# Altium schematic sheet Sheet5.SchDoc
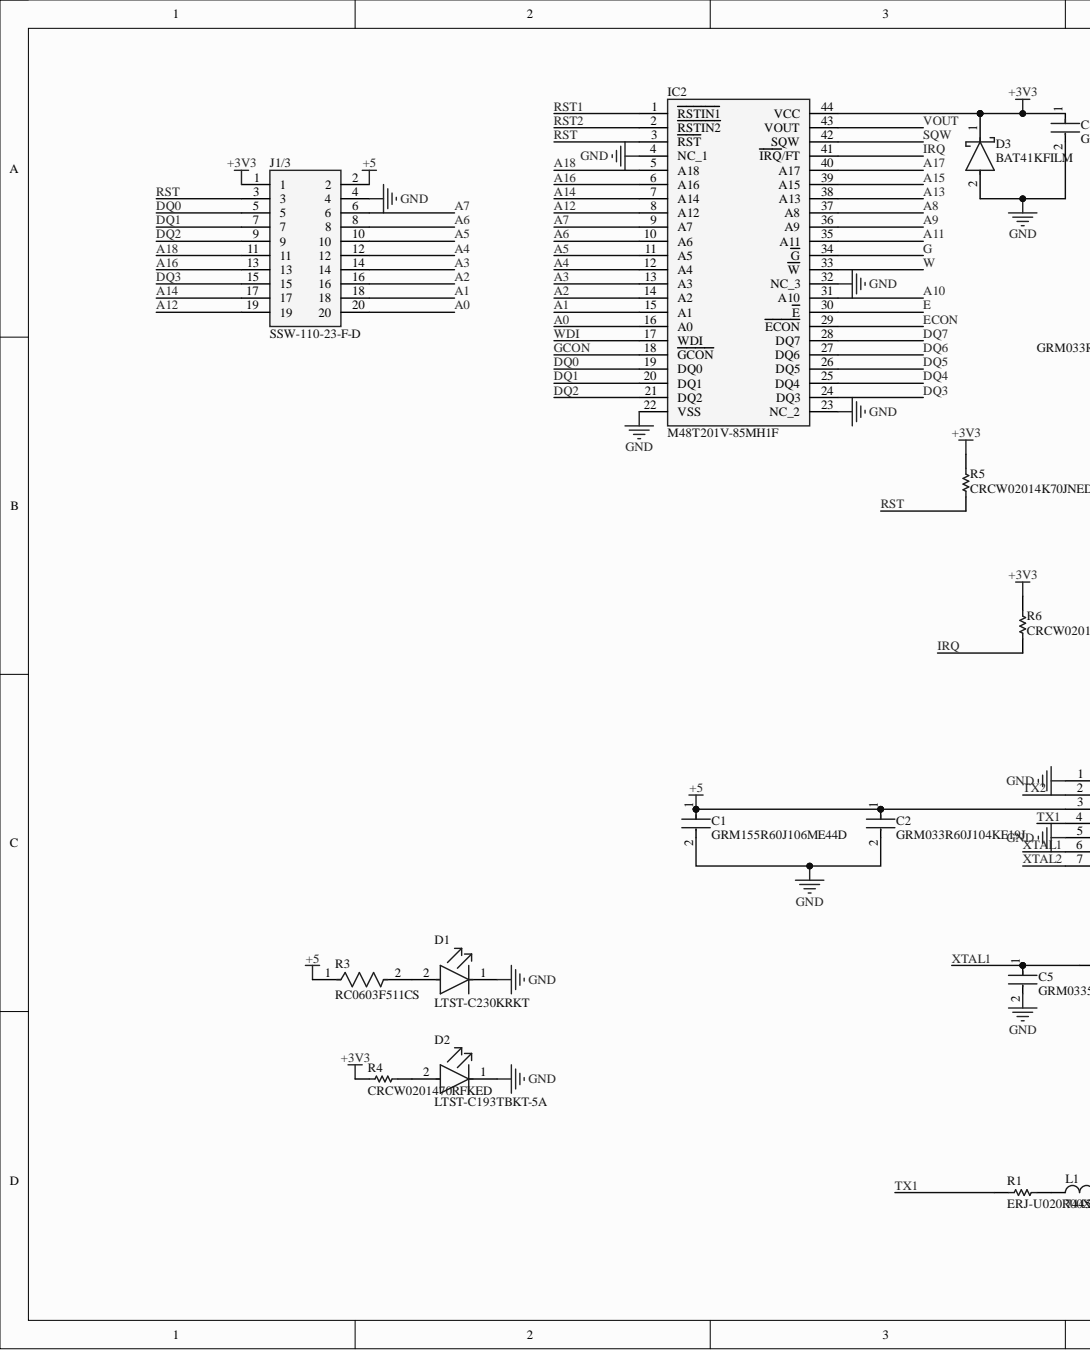
[diagram p0: Sheet5.SchDoc, left]
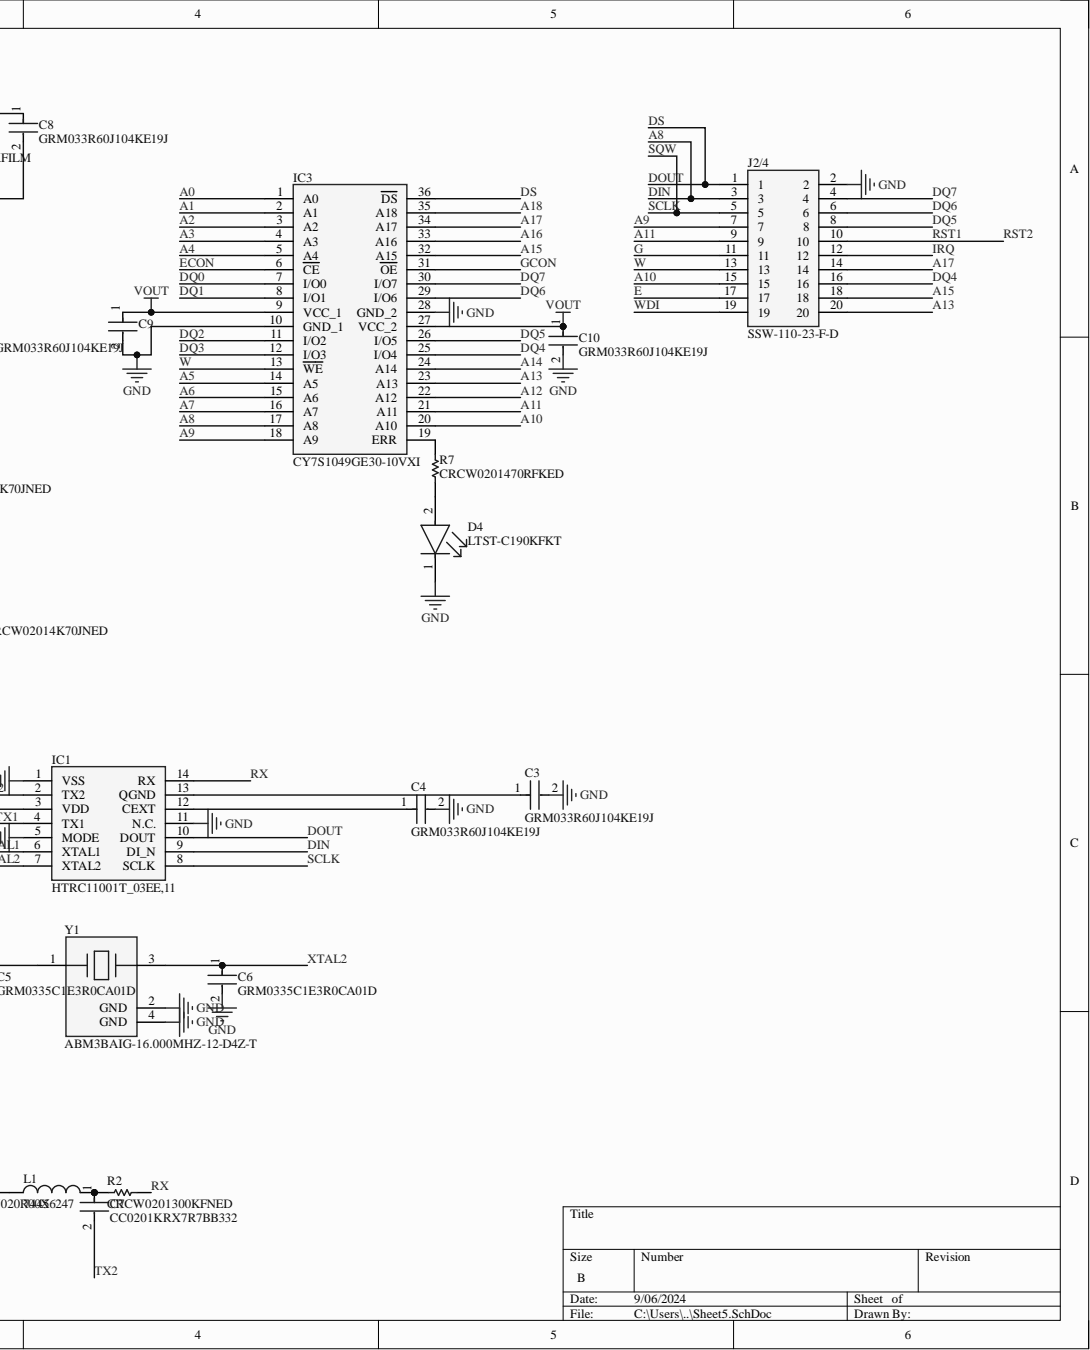
[diagram p1: Sheet5.SchDoc, right]

## Parts
C1  GRM155R60J106ME44D  [FP-GRM155-0_2-IPC_B]
C2  GRM033R60J104KE19J  [FP-GRM033-0_03-MFG]
C3  GRM033R60J104KE19J  [FP-GRM033-0_03-MFG]
C4  GRM033R60J104KE19J  [FP-GRM033-0_03-MFG]
C5  GRM0335C1E3R0CA01D  [FP-GRM033-0_03-MFG]
C6  GRM0335C1E3R0CA01D  [FP-GRM033-0_03-MFG]
C7  CC0201KRX7R7BB332  [FP-CC0201-BA-MFG]
C8  GRM033R60J104KE19J  [FP-GRM033-0_03-MFG]
C9  GRM033R60J104KE19J  [FP-GRM033-0_03-MFG]
C10  GRM033R60J104KE19J  [FP-GRM033-0_03-MFG]
D1  LTST-C230KRKT  [FP-LTST-C230KRKT-MFG]
D2  LTST-C193TBKT-5A  [FP-LTST-C193TBKT-5A-MFG]
D3  BAT41KFILM  [FP-SOD-523-MFG]
D4  LTST-C190KFKT  [FP-LTST-C190KFKT-MFG]
IC1  HTRC11001T_03EE,11  [SOIC127P600X175-14N]
IC2  M48T201V-85MH1F  [SOP81P1210X305-44N]
IC3  CY7S1049GE30-10VXI  [CY7S1049GE3010VXI]
J1/3  SSW-110-23-F-D  [RHDR20W79P254_2X10_2591X495X851P]
J2/4  SSW-110-23-F-D  [RHDR20W79P254_2X10_2591X495X851P]
L1  74456247  [WE-PD4_Type L]
R1  ERJ-U020R00X  [RESC1005X40X25NL05T10]
R2  CRCW0201300KFNED  [RESC0603X28X15NL05T05]
R3  RC0603F511CS  [FP-RC0603-IPC_A]
R4  CRCW0201470RFKED  [RESC0603X28X15LL05T05]
R5  CRCW02014K70JNED  [RESC0603X28X15NL05T05]
R6  CRCW02014K70JNED  [RESC0603X28X15NL05T05]
R7  CRCW0201470RFKED  [RESC0603X28X15LL05T05]
Y1  ABM3BAIG-16.000MHZ-12-D4Z-T  [FP-ABM3BAIG-MFG]

## Nets
+3V3: C8.1 D3.1 IC2.44(VCC) J1/3.1 R4.1 R5.2 R6.2
+5: C1.1 C2.1 IC1.3(VDD) J1/3.2 R3.1
GND: C1.2 C2.2 C3.2 C4.2 C5.2 C6.2 C8.2 C9.2 C10.2 D1.1 D2.1 D3.2 D4.1 IC1.1(VSS) IC1.5(MODE) IC1.11(N.C.) IC2.4(NC_1) IC2.22(VSS) IC2.23(NC_2) IC2.32(NC_3) IC3.10(GND_1) IC3.28(GND_2) J1/3.4 J2/4.2 Y1.2(GND) Y1.4(GND)
A0: IC2.16(A0) IC3.1(A0) J1/3.20
A1: IC2.15(A1) IC3.2(A1) J1/3.18
A2: IC2.14(A2) IC3.3(A2) J1/3.16
A3: IC2.13(A3) IC3.4(A3) J1/3.14
A4: IC2.12(A4) IC3.5(A4) J1/3.12
A5: IC2.11(A5) IC3.14(A5) J1/3.10
A6: IC2.10(A6) IC3.15(A6) J1/3.8
A7: IC2.9(A7) IC3.16(A7) J1/3.6
A8: IC1.9(DI_N) IC2.37(A8) IC3.17(A8) J2/4.3
A9: IC2.36(A9) IC3.18(A9) J2/4.7
A10: IC2.31(A10) IC3.20(A10) J2/4.15
A11: IC2.35(A11) IC3.21(A11) J2/4.9
A12: IC2.8(A12) IC3.22(A12) J1/3.19
A13: IC2.38(A13) IC3.23(A13) J2/4.20
A14: IC2.7(A14) IC3.24(A14) J1/3.17
A15: IC2.39(A15) IC3.32(A15) J2/4.18
A16: IC2.6(A16) IC3.33(A16) J1/3.13
A17: IC2.40(A17) IC3.34(A17) J2/4.14
A18: IC2.5(A18) IC3.35(A18) J1/3.11
DOUT: IC1.10(DOUT) IC3.36(DS) J2/4.1
DQ0: IC2.19(DQ0) IC3.7(I/O0) J1/3.5
DQ1: IC2.20(DQ1) IC3.8(I/O1) J1/3.7
DQ2: IC2.21(DQ2) IC3.11(I/O2) J1/3.9
DQ3: IC2.24(DQ3) IC3.12(I/O3) J1/3.15
DQ4: IC2.25(DQ4) IC3.25(I/O4) J2/4.16
DQ5: IC2.26(DQ5) IC3.26(I/O5) J2/4.8
DQ6: IC2.27(DQ6) IC3.29(I/O6) J2/4.6
DQ7: IC2.28(DQ7) IC3.30(I/O7) J2/4.4
E: IC2.30(E) J2/4.17
ECON: IC2.29(ECON) IC3.6(CE)
G: IC2.34(G) J2/4.11
GCON: IC2.18(GCON) IC3.31(OE)
IRQ: IC2.41(IRQ/FT) J2/4.12 R6.1
RST: IC2.3(RST) J1/3.3 R5.1
RST1: IC2.1(RSTIN1) IC2.2(RSTIN2) J2/4.10
RX: IC1.14(RX) R2.2
SCLK: IC1.8(SCLK) IC2.42(SQW) J2/4.5
TX1: IC1.4(TX1) R1.1
TX2: C7.2 IC1.2(TX2)
VOUT: C9.1 C10.1 IC2.43(VOUT) IC3.9(VCC_1) IC3.27(VCC_2)
W: IC2.33(W) IC3.13(WE) J2/4.13
WDI: IC2.17(WDI) J2/4.19
XTAL1: C5.1 IC1.6(XTAL1) Y1.1
XTAL2: C6.1 IC1.7(XTAL2) Y1.3
NetC3_1: C3.1 IC1.13(QGND)
NetC4_1: C4.1 IC1.12(CEXT)
NetC7_1: C7.1 L1.2 R2.1
NetD1_2: D1.2 R3.2
NetD2_2: D2.2 R4.2
NetD4_2: D4.2 R7.1
NetIC3_19: IC3.19(ERR) R7.2
NetL1_1: L1.1 R1.2

## Library data of the parts
C1 = CMP-2000-05265-2: CAP CER 10UF 6.3V X5R 0402; Tolerance: 20%; Capacitance: 10uF; Case Code (Imperial): 0402; Case Code (Metric): 1005; Case/Package: 0402; Component Kind: Standard; Depth: 0.5mm; Dielectric: X5R; Height: 0.5mm; Lead Free: Lead Free; Length: 1mm; Library Name: Altium Content Vault; Max Operating Temperature: 85°C; Min Operating Temperature: -55°C; Mount: Surface Mount; Package Quantity: 10000; Packaging: Tape and Reel; REACH SVHC: No SVHC; RoHS Compliant: Yes; Series: GRM; Termination: SMD/SMT; Thickness: 0.022inch; Voltage: 6.3V; Voltage Rating: 6.3V; Voltage Rating (DC): 6.3V; Width: 0.5mm
C2-C4 C8-C10 = CMP-2010-01258-2: Chip Multilayer Ceramic Capacitors for General Purpose, 0201, 0.10uF, X5R, 15%, 10%, 6.3V; Capacitance: 100nF; Case Code (Imperial): 0201; Case Code (Metric): 0603; Case/Package: 0201; Component Kind: Standard; Depth: 0.3mm; Dielectric: X5R; Height: 0.3mm; Lead Free: Lead Free; Length: 0.6mm; Library Name: Altium Content Vault; Max Operating Temperature: 85°C; Min Operating Temperature: -55°C; Mount: Surface Mount; Package Quantity: 50000; Packaging: Tape and Reel; Radiation Hardening: No; Termination: SMD/SMT; Thickness: 0.013inch; Tolerance: 10%; Voltage Rating: 6.3V; Voltage Rating (DC): 6.3V; Width: 0.012inch
C5 C6 = CMP-2010-01368-2: Chip Multilayer Ceramic Capacitors for General Purpose, 0201, 3.0pF, C0G, 30ppm/°C, 0.25pF, 25V; Capacitance: 3pF; Case Code (Imperial): 0201; Case Code (Metric): 0603; Case/Package: 0201; Component Kind: Standard; Depth: 0.3mm; Dielectric: C0G; Height: 0.3mm; Lead Free: Lead Free; Length: 0.6mm; Library Name: Altium Content Vault; Max Operating Temperature: 125°C; Min Operating Temperature: -55°C; Mount: Surface Mount; Package Quantity: 15000; Packaging: Tape and Reel; REACH SVHC: No SVHC; Termination: SMD/SMT; Thickness: 0.013inch; Voltage Rating: 25V; Voltage Rating (DC): 25V; Width: 0.012inch
C7 = CMP-03422-001270-1: CAP CER 3300PF 16V X7R 0201; Manufacturer: Yageo; Capacitance: 3.3 nF; Material: Ceramic; Temperature Coefficient: 15%; Tolerance: 0.1; VALUE: 3.3 nF; Automotive: No; Category: Cap; Component Kind: Standard; ComponentLink2Description: Datasheet; ComponentLink2URL: http://www.yageo.com/documents/recent/UPY-GPHC_X5R_4V-to-50V_25.pdf; Device Class L1: Passive Components; Device Class L2: Capacitors; Device Class L3: Ceramic Capacitors; Digikey Description: CAP CER 3300PF 16V X7R 0201; Footprint Url: http://www.yageo.com/exep/pages/download/literatures/UPY-C_GEN_24.pdf; Height: 0.33mm; Ipc Land Pattern Name: CAPC6030X30; Lead Free: Yes; Library Name: Altium Content Vault; Manufacturer Part Number: CC0201KRX7R7BB332; Mouser Description: Multilayer Ceramic Capacitors MLCC - SMD/SMT 3.3nF 16V X7R 10%; Octopart: https://octopart.com/cc0201krx7r7bb332-yageo-9292620; Package: CC0201-BA; RoHS: TRUE; Signal Integrity: Guessed Model; Temperature: -55°C to +85°C; Temperature Characteristic: X7R; Temperature Range High: +85°C; Temperature Range Low: -55°C; Voltage Rating: 16 V
D1 = CMP-22017-000041-1: LED RED CLEAR CHIP SMD; Dominant Wavelength: 631nm; Forward Current: 30mA; Forward Voltage: 2V; Lens Color: Colorless; Lens Style: Diffused; Luminous Intensity: 54mcd; Number of Elements: 1; Power Dissipation: 75mW; Reverse Voltage: 5V; Test Current: 20mA; Viewing Angle: 130°; Wavelength: 639nm; Case Code (Imperial): 1206; Case Code (Metric): 3216; Case/Package: 1206; Color: Red; Component Kind: Standard; Depth: 1.6mm; Height: 1.1mm; Illumination Color: Red; Lead Free: Lead Free; Length: 3.2mm; Lens Transparency: Clear; Library Name: Altium Content Vault; Max Operating Temperature: 85°C; Min Operating Temperature: -55°C; Mount: Surface Mount; Number of LEDs: 1; Number of Pins: 2; Package Quantity: 1; Packaging: Tape and Reel; Power Rating: 75mW; Radiation Hardening: No; RoHS Compliant: Yes; Width: 1.6mm
D2 = CMP-2000-06624-2: LED BLUE CLEAR CHIP SMD; Dominant Wavelength: 475nm; Forward Current: 20mA; Forward Voltage: 2.8V; Lens Color: Colorless; Lens Style: Diffused; Luminous Intensity: 15mcd; Number of Elements: 1; Power Dissipation: 76mW; Reverse Voltage: 5V; Test Current: 5mA; Viewing Angle: 130°; Case Code (Imperial): 0603; Case Code (Metric): 1608; Case/Package: 0603; Color: Blue; Component Kind: Standard; Depth: 0.8mm; Height: 0.35mm; Illumination Color: Blue; Lead Free: Lead Free; Length: 1.6mm; Lens Transparency: Clear; Library Name: Altium Content Vault; Max Operating Temperature: 80°C; Min Operating Temperature: -20°C; Mount: Surface Mount; Number of LEDs: 1; Number of Pins: 2; Package Quantity: 1; Packaging: Tape and Reel; Radiation Hardening: No; RoHS Compliant: Yes; Width: 0.8mm
D3 = CMP-12187-000015-1: DIODE SCHOTTKY 100V 200MA SOD523; Manufacturer: STMicroelectronics; Capacitance: 3pF; Max Junction Temp: +150°C; Max Surge Forward Current: 1A; Peak Reverse Current: 0.1uA; Value: 3pF; Automotive: No; Category: Diode; Component Kind: Standard; ComponentLink2Description: Datasheet; ComponentLink2URL: https://www.st.com/resource/en/datasheet/bat41.pdf; Device Class L1: Discrete Semiconductors; Device Class L2: Diodes; Device Class L3: Schottky Diodes; Digikey Description: DIODE SCHOTTKY 100V 200MA SOD523; Forward Current: 200mA; Height: 0.7mm; Lead Free: Yes; Library Name: Altium Content Vault; Manufacturer Part Number: BAT41KFILM; Max Repetitive Reverse Voltage: 100V; Mouser Description: Schottky Diodes & Rectifiers schottky diode; Octopart: https://octopart.com/bat41kfilm-stmicroelectronics-658186; Package: SOD-523; RoHS: TRUE; Temperature: to +150°C; Temperature Range High: +150°C
D4 = CMP-1674-00008-9: LED ORANGE CLEAR CHIP SMD; Dominant Wavelength: 605nm; Forward Current: 30mA; Forward Voltage: 2V; Lens Color: Colorless; Lens Size: 0.8mm; Lens Style: Flat; Luminous Intensity: 90mcd; Number of Elements: 1; Power Dissipation: 75mW; Reverse Voltage: 5V; Test Current: 20mA; Viewing Angle: 130°; Wavelength: 611nm; Case Code (Imperial): 0603; Case Code (Metric): 1608; Case/Package: 0603; Color: Orange; Component Kind: Standard; Depth: 1.6mm; Height: 0.9mm; Illumination Color: Orange; Lead Free: Lead Free; Length: 3.2mm; Lens Transparency: Clear; Library Name: Altium Content Vault; Max Operating Temperature: 85°C; Min Operating Temperature: -55°C; Mount: Surface Mount; Number of LEDs: 1; Number of Pins: 2; Package Quantity: 3000; Packaging: Tape and Reel; Power Rating: 75mW; Radiation Hardening: No; RoHS Compliant: Yes; Series: -; Width: 0.8mm
IC1 = HTRC11001T_03EE,11: Integrated Circuit; Manufacturer_Name: NXP; Manufacturer_Part_Number: HTRC11001T/03EE,11; Arrow Part Number: HTRC11001T/03EE,11; Arrow Price/Stock: https://www.arrow.com/en/products/htrc11001t03ee11/nxp-semiconductors?region=nac; Component Kind: Standard; Datasheet Link: http://www.nxp.com/docs/en/data-sheet/037031.pdf; Height: 1.75mm; Mouser Part Number: 771-HTRC11001TD-T; Mouser Price/Stock: https://www.mouser.co.uk/ProductDetail/NXP-Semiconductors/HTRC11001T-03EE11?qs=me8TqzrmIYXFfehhhz6%2FBA%3D%3D
IC2 = M48T201V-85MH1F: Integrated Circuit; Manufacturer_Name: STMicroelectronics; Arrow Part Number: M48T201V-85MH1F; Arrow Price/Stock: https://www.arrow.com/en/products/m48t201v-85mh1f/stmicroelectronics?region=nac; Component Kind: Standard; Datasheet Link: https://www.st.com/resource/en/datasheet/m48t201v.pdf; Height: 3.05mm; Manufacturer_Part_Number: M48T201V-85MH1F; Mouser Part Number: 511-M48T201V-85MH1F; Mouser Price/Stock: https://www.mouser.co.uk/ProductDetail/STMicroelectronics/M48T201V-85MH1F?qs=du%252Bo5tJIeNJFhGr0KezC0g%3D%3D
IC3 = CY7S1049GE30-10VXI: Integrated Circuit; Manufacturer_Name: Infineon; Arrow Part Number: CY7S1049GE30-10VXI; Arrow Price/Stock: https://www.arrow.com/en/products/cy7s1049ge30-10vxi/infineon-technologies-ag?utm_currency=USD&region=nac; Component Kind: Standard; Datasheet Link: https://www.mouser.co.uk/datasheet/2/196/Infineon_CY7C1049G_CY7C1049GE_4_Mbit__512K_words_8-3446047.pdf; Height: 3.7592mm; Manufacturer_Part_Number: CY7S1049GE30-10VXI; Mouser Part Number: 727-CY7S1049GE300VXI; Mouser Price/Stock: https://www.mouser.co.uk/ProductDetail/Infineon-Technologies/CY7S1049GE30-10VXI?qs=2De2tSDgB02aBHDh7fjT%2FA%3D%3D
J1/3 J2/4 = SSW-110-23-F-D: Connector; Manufacturer_Name: SAMTEC; Arrow Part Number: SSW-110-23-F-D; Arrow Price/Stock: https://www.arrow.com/en/products/ssw-110-23-f-d/samtec?region=nac; Component Kind: Standard; Datasheet Link: http://suddendocs.samtec.com/prints/ssw-1xx-xx-xxx-x-xx-xxx-xx-mkt.pdf; Height: 8.51mm; Manufacturer_Part_Number: SSW-110-23-F-D; Mouser Part Number: 200-SSW11023FD; Mouser Price/Stock: https://www.mouser.co.uk/ProductDetail/Samtec/SSW-110-23-F-D?qs=Cqqh%252BS766wni9CoblzTtAA%3D%3D
L1 = CMP-0227-00836-2: NexarPartId: 8917319; Core Material: Ferrite; Current Rating: 600mA; DC Resistance (DCR): 1.315Ω; Inductance: 470µH; Inductance Tolerance: 10%; Saturation Current: 650mA; Self Resonant Frequency: 3.1MHz; Shielding: Unshielded; Test Frequency: 100kHz; Ambient Temperature Range High: 85°C; Ambient Temperature Range Low: -40°C; Case/Package: Nonstandard; Component Kind: Standard; Composition: Wirewound; Depth: 10mm; Height: 5mm; Height - Seated (Max): 5.3086mm; Length: 12.7mm; Library Name: Altium Content Vault; Max DC Current: 600mA; Max Operating Temperature: 125°C; Min Operating Temperature: -40°C; Mount: Surface Mount; Number of Pins: 2; Packaging: Cut Tape (CT); REACH SVHC: Unknown; Resistance: 1.315Ω; RMS Current (Irms): 600mA; RoHS: Compliant; Series Resistance: 1.315Ω; Signal Integrity: Guessed Model; Tolerance: 10%; Width: 10mm
R1 = CMP-2002-06457-1: Manufacturer: Panasonic; Component Kind: Standard; Library Name: Altium Content Vault; Part Number: ERJ-U020R00X
R2 = CMP-2004-00445-1: Manufacturer: Vishay Dale; Component Kind: Standard; Library Name: Altium Content Vault; Part Number: CRCW0201300KFNED; Signal Integrity: Guessed Model
R3 = CMP-13261-005122-1: Res Thick Film 0201 510Ω 1% 0.05W ±250ppm/°C Molded SMD T/R; Max Power Dissipation: 50mW; Resistance: 510R; Voltage Rating (DC): 25V; Case Code (Imperial): 0201; Case Code (Metric): 0603; Case/Package: 0201; Component Kind: Standard; Composition: Thick Film; Contact Plating: Tin; Features: Moisture Resistant; Height: 0.01inch; Lead Free: Lead Free; Length: 0.024inch; Library Name: Altium Content Vault; Max Operating Temperature: 125°C; Min Operating Temperature: -55°C; Number of Terminations: 2; Packaging: Tape and Reel; Radiation Hardening: No; Temperature Coefficient: 250ppm/°C; Tolerance: 1%; Width: 0.012inch
R4 R7 = CMP-2004-00629-1: Manufacturer: Vishay Dale; Component Kind: Standard; Library Name: Altium Content Vault; Part Number: CRCW0201470RFKED
R5 R6 = CMP-2004-00673-1: Manufacturer: Vishay Dale; Component Kind: Standard; Library Name: Altium Content Vault; Part Number: CRCW02014K70JNED
Y1 = CMP-27762-006368-1: Automotive & Industrial Grade Crystal 16MHz ±30ppm 12pF SMD-4 5mm x 3.2mm; Component Kind: Standard; Library Name: Altium Content Vault
